# Altium schematic sheet mcu.SchDoc
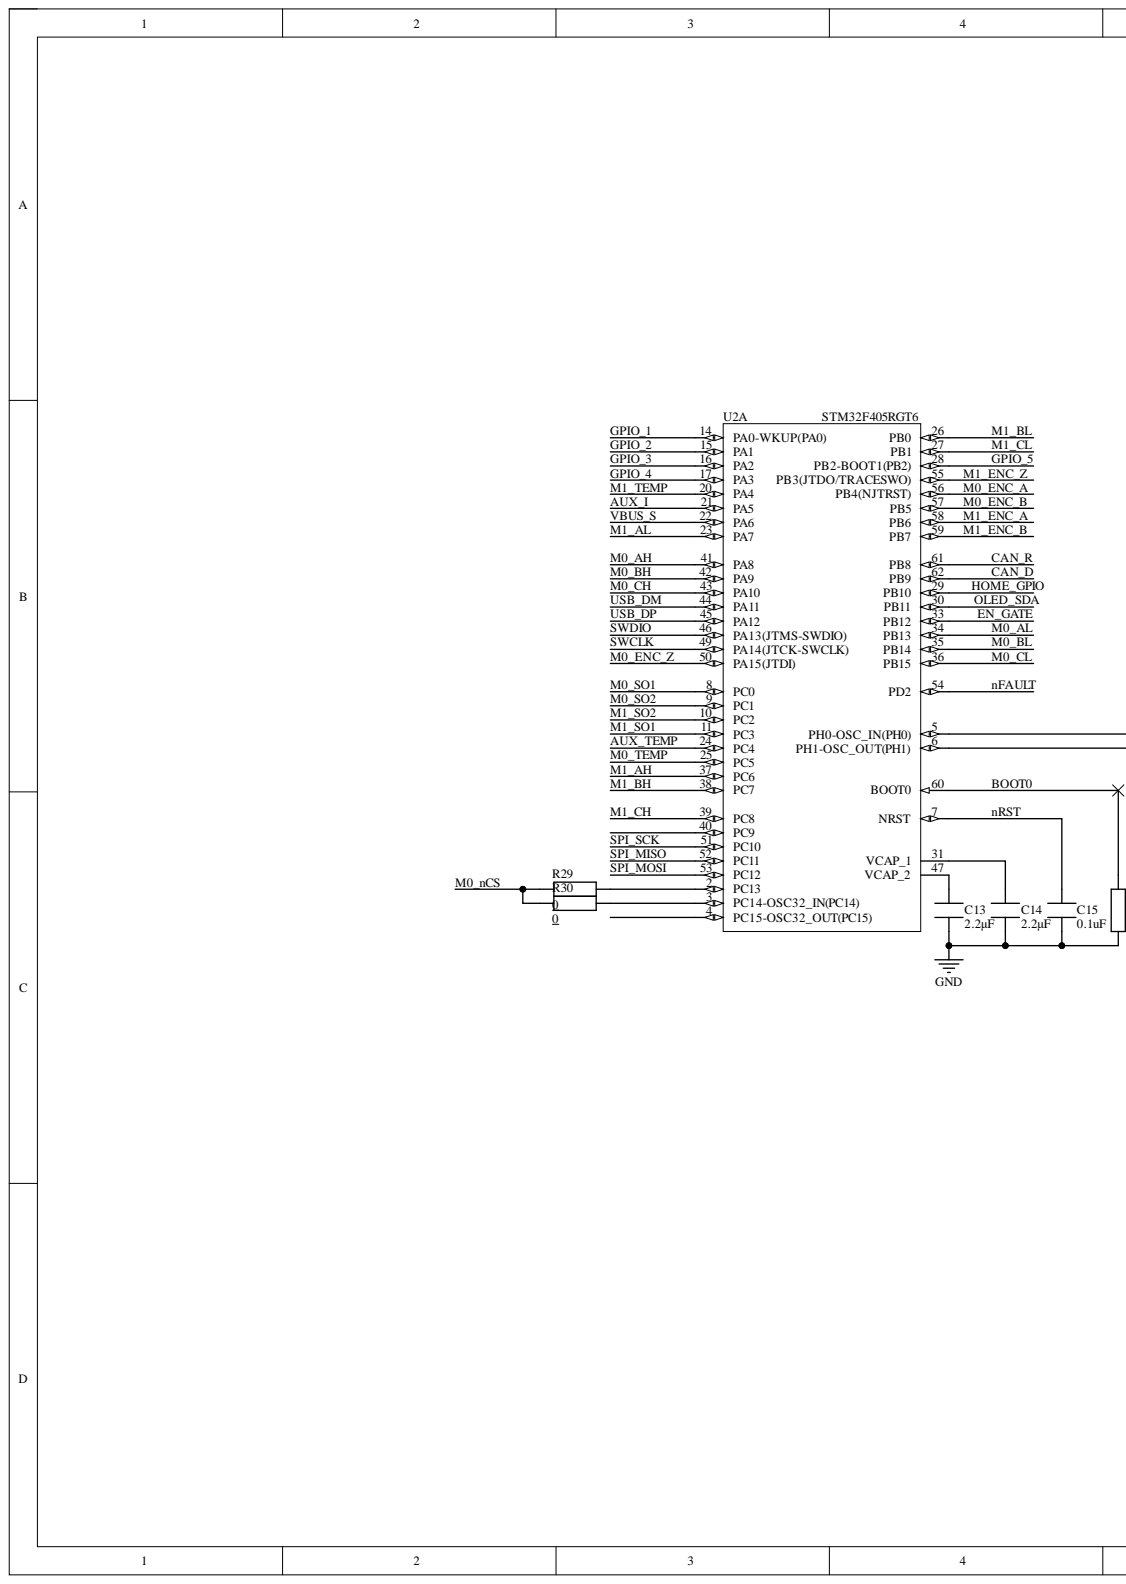
[diagram p0: mcu.SchDoc, left]
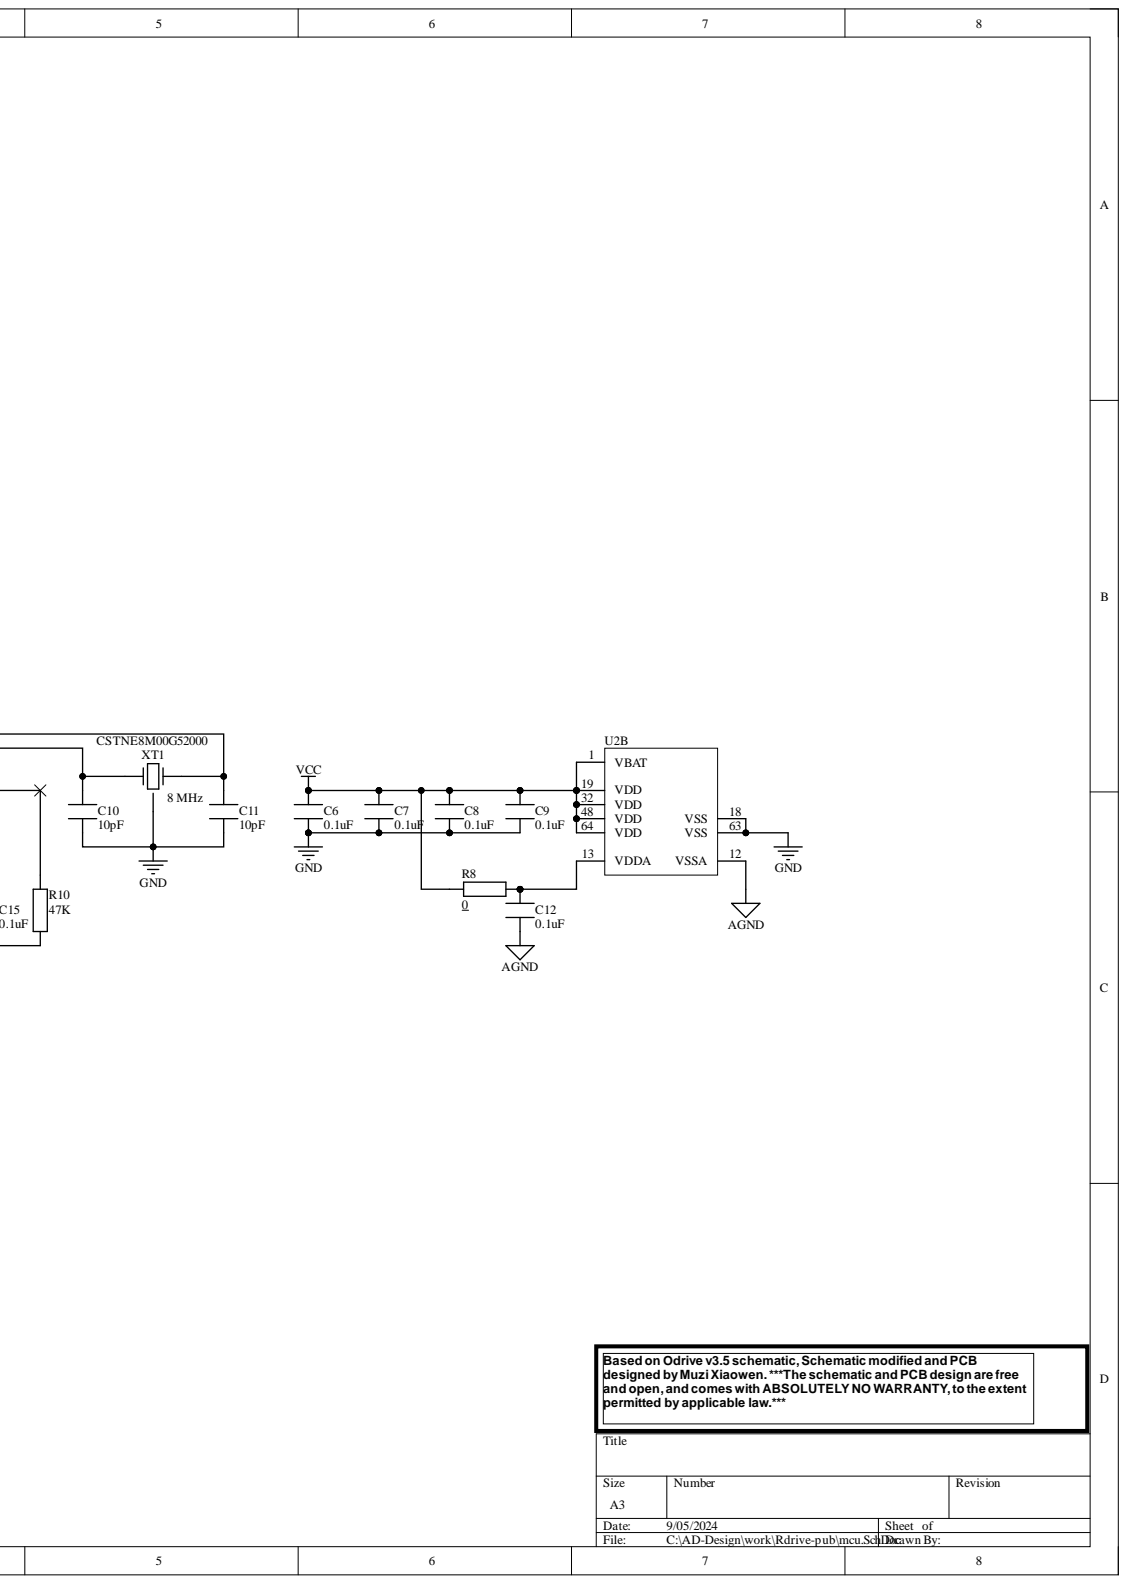
[diagram p1: mcu.SchDoc, right]

## Parts
C6  0.1uF  [CAP_0402]
C7  0.1uF  [CAP_0402]
C8  0.1uF  [CAP_0402]
C9  0.1uF  [CAP_0402]
C10  10pF  [CAP_0402]
C11  10pF  [CAP_0402]
C12  0.1uF  [CAP_0402]
C13  2.2µF  [CAP_0402]
C14  2.2µF  [CAP_0402]
C15  0.1uF  [CAP_0402]
R8  0  [RES_0402]
R10  47K  [RES_0402]
R29  0  [RES_0402]
R30  0  [RES_0402]
U2  (no value)  [STM-LQFP64_N]  (parts A,B)
XT1  value 8 MHz  Load Capacitance: 10pF  Manufacturer Part Number: CSTNE8M00G52000  [OSC3212]  (hidden comment: OSC8MHZ3212)

## Nets
AGND: C12.2 U2.12(VSSA)
GND: C6.2 C7.2 C8.2 C9.2 C10.2 C11.2 C13.1 C14.1 C15.1 R10.1 U2.18(VSS) U2.63(VSS) XT1.2
VCC: C6.1 C7.1 C8.1 C9.1 R8.1 U2.1(VBAT) U2.19(VDD) U2.32(VDD) U2.48(VDD) U2.64(VDD)
AUX_I_1: U2.21(PA5)  [also labelled AUX_I]
AUX_TEMP_1: U2.24(PC4)  [also labelled AUX_TEMP]
BOOT0_1: R10.2 U2.60(BOOT0)  [also labelled BOOT0]
CAN_D_1: U2.62(PB9)  [also labelled CAN_D]
CAN_R_1: U2.61(PB8)  [also labelled CAN_R]
EN_GATE_1: U2.33(PB12)  [also labelled EN_GATE]
GPIO_1_1: U2.14(PA0-WKUP(PA0))  [also labelled GPIO_1]
GPIO_2_1: U2.15(PA1)  [also labelled GPIO_2]
GPIO_3_1: U2.16(PA2)  [also labelled GPIO_3]
GPIO_4_1: U2.17(PA3)  [also labelled GPIO_4]
GPIO_5_1: U2.28(PB2-BOOT1(PB2))  [also labelled GPIO_5]
HOME_GPIO_1: U2.29(PB10)  [also labelled HOME_GPIO]
M0_AH_1: U2.41(PA8)  [also labelled M0_AH]
M0_AL_1: U2.34(PB13)  [also labelled M0_AL]
M0_BH_1: U2.42(PA9)  [also labelled M0_BH]
M0_BL_1: U2.35(PB14)  [also labelled M0_BL]
M0_CH_1: U2.43(PA10)  [also labelled M0_CH]
M0_CL_1: U2.36(PB15)  [also labelled M0_CL]
M0_ENC_A_1: U2.56(PB4(NJTRST))  [also labelled M0_ENC_A]
M0_ENC_B_1: U2.57(PB5)  [also labelled M0_ENC_B]
M0_ENC_Z_1: U2.50(PA15(JTDI))  [also labelled M0_ENC_Z]
M0_nCS_1: R29.2 R30.1  [also labelled M0_nCS]
M0_SO1_1: U2.8(PC0)  [also labelled M0_SO1]
M0_SO2_1: U2.9(PC1)  [also labelled M0_SO2]
M0_TEMP_1: U2.25(PC5)  [also labelled M0_TEMP]
M1_AH_1: U2.37(PC6)  [also labelled M1_AH]
M1_AL_1: U2.23(PA7)  [also labelled M1_AL]
M1_BH_1: U2.38(PC7)  [also labelled M1_BH]
M1_BL_1: U2.26(PB0)  [also labelled M1_BL]
M1_CH_1: U2.39(PC8)  [also labelled M1_CH]
M1_CL_1: U2.27(PB1)  [also labelled M1_CL]
M1_ENC_A_1: U2.58(PB6)  [also labelled M1_ENC_A]
M1_ENC_B_1: U2.59(PB7)  [also labelled M1_ENC_B]
M1_ENC_Z_1: U2.55(PB3(JTDO/TRACESWO))  [also labelled M1_ENC_Z]
M1_SO1_1: U2.11(PC3)  [also labelled M1_SO1]
M1_SO2_1: U2.10(PC2)  [also labelled M1_SO2]
M1_TEMP_1: U2.20(PA4)  [also labelled M1_TEMP]
nFAULT_1: U2.54(PD2)  [also labelled nFAULT]
nRST_1: C15.2 U2.7(NRST)  [also labelled nRST]
OLED_SDA_1: U2.30(PB11)  [also labelled OLED_SDA]
SPI_MISO_1: U2.52(PC11)  [also labelled SPI_MISO]
SPI_MOSI_1: U2.53(PC12)  [also labelled SPI_MOSI]
SPI_SCK_1: U2.51(PC10)  [also labelled SPI_SCK]
SWCLK_1: U2.49(PA14(JTCK-SWCLK))  [also labelled SWCLK]
SWDIO_1: U2.46(PA13(JTMS-SWDIO))  [also labelled SWDIO]
USB_DM_1: U2.44(PA11)  [also labelled USB_DM]
USB_DP_1: U2.45(PA12)  [also labelled USB_DP]
VBUS_S_1: U2.22(PA6)  [also labelled VBUS_S]
NetC10_1: C10.1 U2.6(PH1-OSC_OUT(PH1)) XT1.3
NetC11_1: C11.1 U2.5(PH0-OSC_IN(PH0)) XT1.1
NetC12_1: C12.1 R8.2 U2.13(VDDA)
NetC13_2: C13.2 U2.47(VCAP_2)
NetC14_2: C14.2 U2.31(VCAP_1)
NetR29_1: R29.1 U2.2(PC13)
NetR30_2: R30.2 U2.3(PC14-OSC32_IN(PC14))

Not connected: U2 4(PC15-OSC32_OUT(PC15)) 40(PC9)

## Library data of the parts
C6-C9 C12 C15 = GRM188R71H104KA93D: CAP CER 0.1UF 50V X7R 0603; Manufacturer: Murata Electronics North America; Tolerance: ±10%; Applications: General Purpose; Capacitance: 0.1uF; Category: Capacitors; Component Kind: Standard; ComponentLink1Description: http://www.murata.com/~/media/webrenewal/support/library/catalog/products/capacitor/mlcc/c02e.ashx?la=en-us; ComponentLink1URL: http://www.murata.com/~/media/webrenewal/support/library/catalog/products/capacitor/mlcc/c02e.ashx?la=en-us; Features: -; Height - Seated (Max): -; Lead Spacing: -; Lead Style: -; Library Name: CustomComponents.SchLib; Manufacturer Part Number: GRM188R71H104KA93D; Mounting Type: Surface Mount, MLCC; Operating Temperature: -55°C ~ 125°C; Package / Case: 0603 (1608 Metric); Packaging: Tape & Reel (TR); Part Status: Active; Pricing 1: 4000=0.01822, 8000=0.01663, 12000=0.01584 (USD); Pricing 2: 1=0.12, 10=0.084, 100=0.0396, 500=0.02778, 1000=0.023 (USD); Ratings: -; RoHS: RoHS Compliant; Size / Dimension: 0.063" L x 0.032" W (1.60mm x 0.80mm); Stock: 19812000; Supplier 1: Digi-Key; Supplier 2: Digi-Key; Supplier Part Number 1: 490-1519-2-ND; Supplier Part Number 2: 490-1519-1-ND; Temperature Coefficient: X7R; Thickness (Max): 0.035" (0.90mm); Voltage - Rated: 50V
C10 C11 = GRM1885C1H100JA01D: CAP CER 10PF 50V NP0 0603; Manufacturer: Murata Electronics North America; Temperature Coefficient: C0G, NP0; Tolerance: ±5%; Applications: General Purpose; Capacitance: 10pF; Category: Capacitors; Component Kind: Standard; ComponentLink1Description: http://www.murata.com/~/media/webrenewal/support/library/catalog/products/capacitor/mlcc/c02e.pdf; ComponentLink1URL: http://www.murata.com/~/media/webrenewal/support/library/catalog/products/capacitor/mlcc/c02e.pdf; Features: -; Height - Seated (Max): -; Lead Spacing: -; Lead Style: -; Library Name: CustomComponents.SchLib; Manufacturer Part Number: GRM1885C1H100JA01D; Mounting Type: Surface Mount, MLCC; Operating Temperature: -55°C ~ 125°C; Package / Case: 0603 (1608 Metric); Packaging: Cut Tape (CT); Pricing 1: 1=0.1, 10=0.041, 50=0.0222, 100=0.0187, 250=0.01532, 500=0.0131, 1000=0.0102 (USD); Ratings: -; RoHS: RoHS Compliant; Size / Dimension: 0.063" L x 0.031" W (1.60mm x 0.80mm); Stock 1: 450321; Supplier 1: Digi-Key; Supplier Part Number 1: 490-1403-1-ND; Thickness (Max): 0.035" (0.90mm); Voltage - Rated: 50V
C13 C14 = GRM188R61C225KE15D: CAP CER 2.2UF 16V X5R 0603; Manufacturer: Murata Electronics North America; Tolerance: ±10%; Applications: General Purpose; Capacitance: 2.2µF; Category: Capacitors; Component Kind: Standard; ComponentLink1Description: http://www.murata.com/~/media/webrenewal/support/library/catalog/products/capacitor/mlcc/c02e.pdf; ComponentLink1URL: http://www.murata.com/~/media/webrenewal/support/library/catalog/products/capacitor/mlcc/c02e.pdf; Features: -; Height - Seated (Max): -; Lead Spacing: -; Lead Style: -; Library Name: CustomComponents.SchLib; Manufacturer Part Number: GRM188R61C225KE15D; Mounting Type: Surface Mount, MLCC; Operating Temperature: -55°C ~ 85°C; Package / Case: 0603 (1608 Metric); Packaging: Cut Tape (CT); Pricing 1: 1=0.12, 10=0.08, 50=0.046, 100=0.038, 250=0.032, 500=0.0268, 1000=0.022 (USD); Ratings: -; RoHS: RoHS Compliant; Size / Dimension: 0.063" L x 0.031" W (1.60mm x 0.80mm); Stock 1: 1255740; Supplier 1: Digi-Key; Supplier Part Number 1: 490-3296-1-ND; Temperature Coefficient: X5R; Thickness (Max): 0.035" (0.90mm); Voltage - Rated: 16V
R8 R29 R30 = RC0603FR-071KL: RES SMD 1K OHM 1% 1/10W 0603; Manufacturer: Yageo; Power (Watts): 0.1W, 1/10W; Temperature Coefficient: ±100ppm/°C; Category: Resistors; Component Kind: Standard; ComponentLink1Description: http://www.yageo.com/documents/recent/PYu-RC_Group_51_RoHS_L_04.pdf; ComponentLink1URL: http://www.yageo.com/documents/recent/PYu-RC_Group_51_RoHS_L_04.pdf; Composition: Thick Film; Features: Moisture Resistant; Height: 0.022" (0.55mm); Library Name: CustomComponents.SchLib; Manufacturer Part Number: RC0603FR-071KL; Number of Terminations: 2; Operating Temperature: -55°C ~ 155°C; Package / Case: 0603 (1608 Metric); Packaging: Cut Tape (CT); Pricing 1: 1=0.1, 10=0.014, 25=0.01, 100=0.0057, 250=0.00436, 500=0.00348, 1000=0.00257, 2500=0.00223 (USD); Resistance (Ohms): 1k; RoHS: RoHS Compliant; Size / Dimension: 0.063" L x 0.031" W (1.60mm x 0.80mm); Stock 1: 6734836; Supplier 1: Digi-Key; Supplier Device Package: 0603; Supplier Part Number 1: 311-1.00KHRCT-ND; Tolerance: ±1%
R10 = RC0603FR-0747KL: RES SMD 47K OHM 1% 1/10W 0603; Manufacturer: Yageo; Power (Watts): 0.1W, 1/10W; Temperature Coefficient: ±100ppm/°C; Category: Resistors; Component Kind: Standard; ComponentLink1Description: http://www.yageo.com/documents/recent/PYu-RC_Group_51_RoHS_L_04.pdf; ComponentLink1URL: http://www.yageo.com/documents/recent/PYu-RC_Group_51_RoHS_L_04.pdf; Composition: Thick Film; Features: Moisture Resistant; Height: 0.022" (0.55mm); Library Name: CustomComponents.SchLib; Manufacturer Part Number: RC0603FR-0747KL; Number of Terminations: 2; Operating Temperature: -55°C ~ 155°C; Package / Case: 0603 (1608 Metric); Packaging: Cut Tape (CT); Pricing 1: 1=0.1, 10=0.014, 25=0.01, 100=0.0057, 250=0.00436, 500=0.00348, 1000=0.00257, 2500=0.00223 (USD); Resistance (Ohms): 47k; RoHS: RoHS Compliant; Size / Dimension: 0.063" L x 0.031" W (1.60mm x 0.80mm); Stock 1: 930868; Supplier 1: Digi-Key; Supplier Device Package: 0603; Supplier Part Number 1: 311-47.0KHRCT-ND; Tolerance: ±1%
U2 = STM32F405RGT6: ARM Cortex-M4 32-bit MCU+FPU, 210 DMIPS, 1024 kB Flash, 192 kB Internal RAM, 51 I/Os, 64-pin LQFP, -40 to 85 degC, Tray; Manufacturer: STMicroelectronics; D/A Converter: 2x12-bit; FLASH Size (Prog) (kB): 1024; I/Os (High Current): 51; Internal RAM Size (kB): 192; Operating Frequency(F) (Processor speed) (MHz): 168; Supply Voltage(Vcc) max (V): 3.6; Supply Voltage(Vcc) min (V): 1.8; Component Kind: Standard; ComponentLink1Description: Manufacturer URL; ComponentLink1URL: http://www.st.com/web/en/home.html; ComponentLink2Description: Datasheet; ComponentLink2URL: http://www.st.com/st-web-ui/static/active/en/resource/technical/document/datasheet/DM00037051.pdf; ComponentLink3Description: Package Specification; ComponentLink3URL: http://www.st.com/st-web-ui/static/active/en/resource/technical/document/datasheet/DM00037051.pdf; Core: ARM Cortex-M4; DatasheetVersion: Rev. 4; Library Name: STMicroelectronics STM32 F4.IntLib; Mounting Technology: Surface Mount; PackageDescription: 64-Pin Low Profile Quad Flat Package, 10 x 10 mm Body, 0.5 mm Pitch; PackageReference: LQFP64; PackageVersion: Rev. 4, 06/2013; Packing: Tray; PartNumber: STM32F405RGT6; Supplier 1: Digi-Key; Supplier 2: Farnell; Supplier 3: Newark; Supplier 4: Mouser; Supplier Part Number 1: 497-11767-ND; Supplier Part Number 2: 2064363; Supplier Part Number 3: 73T2329; Supplier Part Number 4: 511-STM32F405RGT6
XT1 = OSC8MHZ3212: Crystal 8MHz ±10ppm 10pF 200 Ohm -10°C ~ 60°C Surface Mount 4-SMD, No Lead (DFN, LCC); Manufacturer: Abracon LLC; Operating Mode: Fundamental; Category: Crystals, Oscillators, Resonators; Component Kind: Standard; ComponentLink1Description: http://www.abracon.com/Resonators/abm3b.pdf; ComponentLink1URL: http://www.abracon.com/Resonators/abm3b.pdf; Frequency Stability: ±10ppm; Frequency Tolerance: ±10ppm; Height - Seated (Max): 0.043" (1.10mm); Library Name: CustomComponents.SchLib; Mounting Type: Surface Mount; Operating Temperature: -10°C ~ 60°C; Package / Case: 4-SMD, No Lead; Packaging: Cut Tape (CT); Part Status: Active; Pricing 1: 1=0.69, 10=0.578, 50=0.5198, 100=0.462, 500=0.4389 (USD); Pricing 2: 1000=0.336, 3000=0.315, 5000=0.3045, 10000=0.294 (USD); Ratings: -; RoHS: RoHS Compliant; Size / Dimension: 0.197" L x 0.126" W (5.00mm x 3.20mm); Stock: 35437; Supplier 1: Digi-Key; Supplier 2: Digi-Key; Supplier Part Number 1: 535-9721-1-ND; Supplier Part Number 2: 535-9721-2-ND; Type: MHz Crystal
